# Altium schematic sheet PowerUnit.SchDoc(PowerUnit)
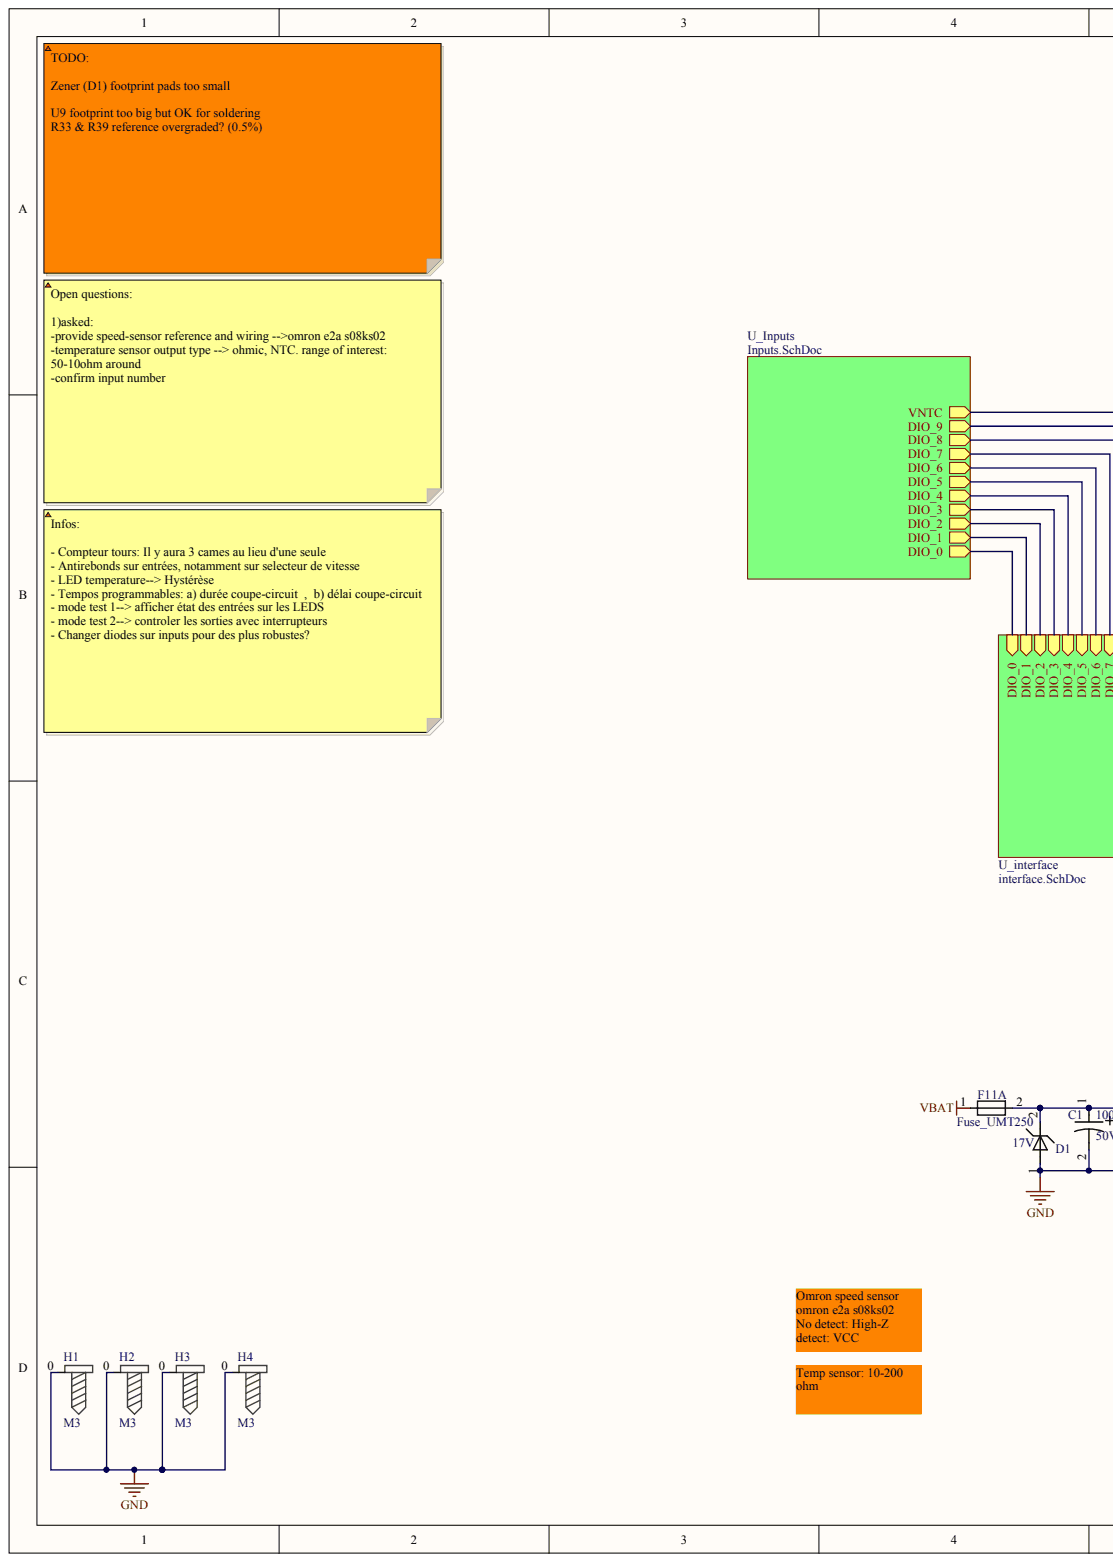
[diagram p0: PowerUnit.SchDoc, left]
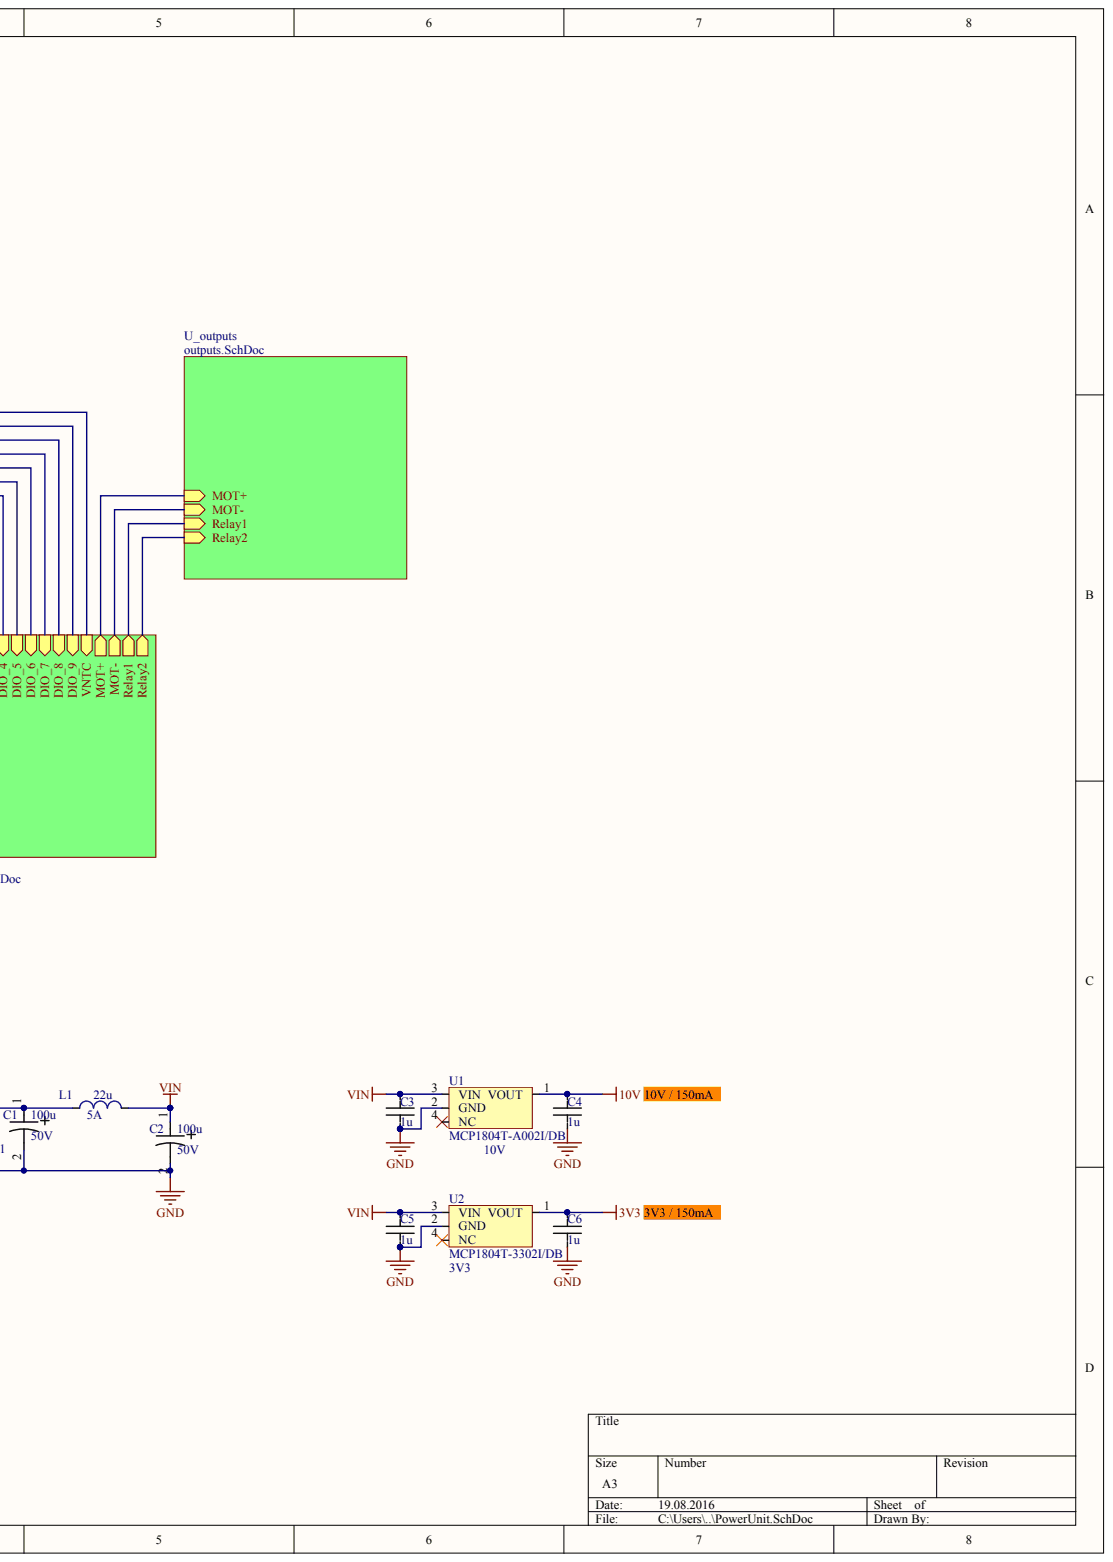
[diagram p1: PowerUnit.SchDoc, right]

## Parts
C1  value 100u  Rated voltage: 50V  (hidden comment: C_pol)
C2  value 100u  Rated voltage: 50V  (hidden comment: C_pol)
C3  1u
C4  1u
C5  1u
C6  1u
D1  Vz: 17V  (hidden comment: Zener)
F1  Fuse_UMT250  Calibre: 1A
H1  M3
H2  M3
H3  M3
H4  M3
L1  value 22u  current: 5A  (hidden comment: Inductor)
U1  MCP1804T-A002I/DB  Output voltage: 10V
U2  MCP1804T-3302I/DB  Output voltage: 3V3

## Nets
3V3: C6.1 U2.1(VOUT)
10V: C4.1 U1.1(VOUT)
GND: C1.2 C2.2 C3.2 C4.2 C5.2 C6.2 D1.1 H1.0 H2.0 H3.0 H4.0 U1.2(GND) U2.2(GND)
VBAT: F1.1
VIN: C2.1 C3.1 C5.1 L1.2 U1.3(VIN) U2.3(VIN)
NetC1_1: C1.1 D1.2 F1.2 L1.1
NetU1_4: U1.4(NC)
NetU2_4: U2.4(NC)
DIO_0:
DIO_1:
DIO_2:
DIO_3:
DIO_4:
DIO_5:
DIO_6:
DIO_7:
DIO_8:
DIO_9:
MOT+:
MOT-:
RELAY1:
RELAY2:
VNTC:

## Library data of the parts
C1 C2 = (no symbol name): Manufacturer: Nichicon; Manufacturer PartNum: UVR1H101MPD1TD; Digikey ref: 493-5916-1-ND
C3-C6 = (no symbol name): Manufacturer PartNum: GRM155R61E105MA12D; Manufacturer: Murata; Nominal voltage: 25V; value: 1u; Digikey ref: 490-10018-1-ND
D1 = (no symbol name): Manufacturer: ON Semi; Manufacturer PartNum: 1N5354BG; Digikey ref: 1N5354BGOS-ND
F1 = (no symbol name): Manufacturer PartNum: 3403.0166.11; Manufacturer: Schurter Inc; Unominal: 250V; Type: Temporisé; Digikey ref: 486-1247-ND; Farnell ref: 1221090; Mouser ref: 693-3403.0166.11
L1 = (no symbol name): Manufacturer PartNum: 7447709220; Manufacturer: Wurth Electronics Inc; Digikey ref: 732-1243-1-ND; Digikey ref 2 (was unavailable): SRP1038A-220MCT-ND
U1 U2 = (no symbol name): Manufacturer: Microchip
  U1: Digikey ref: MCP1804T-A002I/DBCT-ND; Manufacturer PartNum: MCP1804T-A002I/DB
  U2: Digikey ref: MCP1804T-3302I/DBCT-ND; Manufacturer PartNum: MCP1804T-3302I/DB
H1-H4 = (no symbol name): value: M3
